# Altium schematic sheet Power.SchDoc(Power)
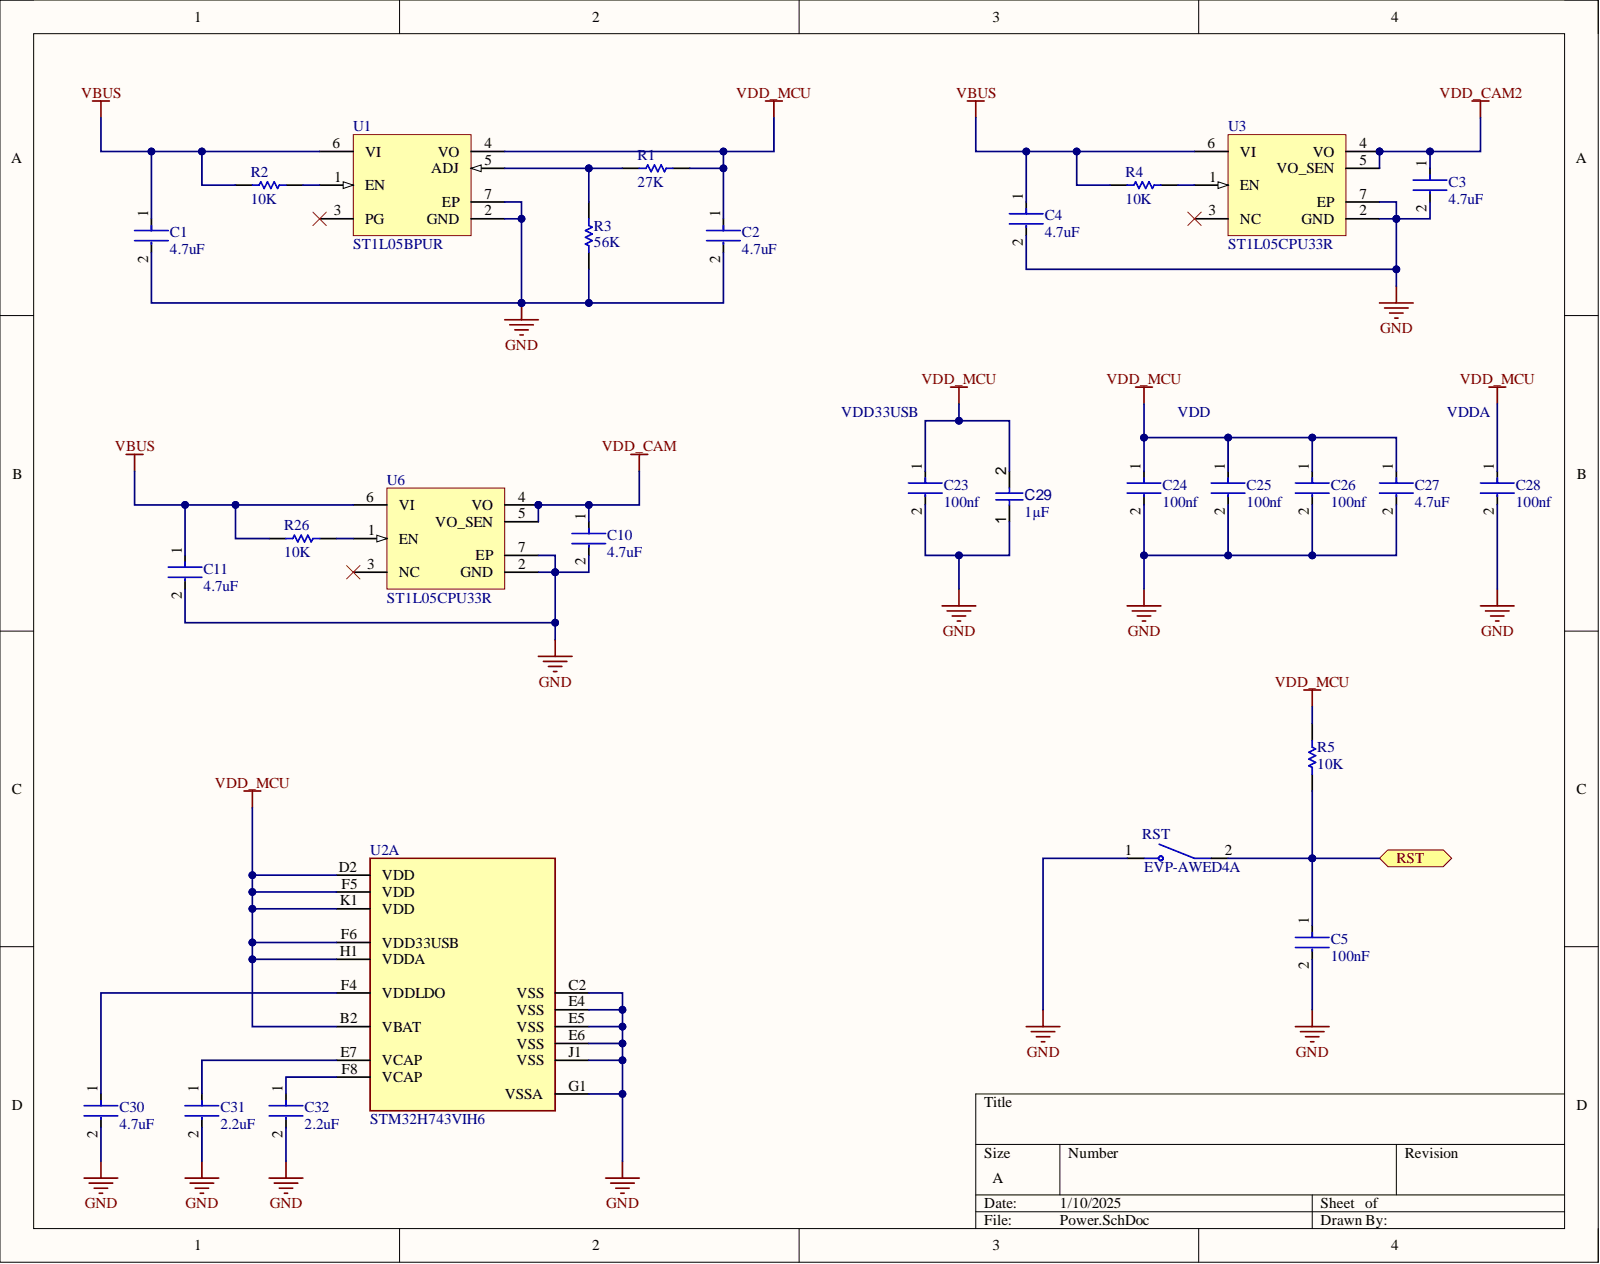
[diagram p0: Power.SchDoc]

## Parts
C1  4.7uF
C2  4.7uF
C3  4.7uF
C4  4.7uF
C5  100nF
C10  4.7uF
C11  4.7uF
C23  100nf
C24  100nf
C25  100nf
C26  100nf
C27  4.7uF
C28  100nf
C29  value 1µF  (hidden comment: Capacitor 1 uF +/- 10% 6.3 V 0402)
C30  4.7uF
C31  2.2uF
C32  2.2uF
R1  27K
R2  10K
R3  56K
R4  10K
R5  10K
R26  10K
RST  EVP-AWED4A
U1  ST1L05BPUR
U2  STM32H743VIH6  (part A)
U3  ST1L05CPU33R
U6  ST1L05CPU33R

## Ports
RST

## Nets
GND: C1.2 C2.2 C3.2 C4.2 C5.2 C10.2 C11.2 C23.2 C24.2 C25.2 C26.2 C27.2 C28.2 C29.1 C30.2 C31.2 C32.2 R3.1 RST.1 U1.2(GND) U1.7(EP) U2.C2(VSS) U2.E4(VSS) U2.E5(VSS) U2.E6(VSS) U2.G1(VSSA) U2.J1(VSS) U3.2(GND) U3.7(EP) U6.2(GND) U6.7(EP)
VBUS: C1.1 C4.1 C11.1 R2.2 R4.2 R26.2 U1.6(VI) U3.6(VI) U6.6(VI)
VDD_CAM: C10.1 U6.4(VO) U6.5(VO_SEN)
VDD_CAM2: C3.1 U3.4(VO) U3.5(VO_SEN)
VDD_MCU: C2.1 C23.1 C24.1 C25.1 C26.1 C27.1 C28.1 C29.2 R1.2 R5.1 U1.4(VO) U2.B2(VBAT) U2.D2(VDD) U2.F5(VDD) U2.F6(VDD33USB) U2.H1(VDDA) U2.K1(VDD)
RST: C5.1 R5.2 RST.2 ; port RST
NetC30_1: C30.1 U2.F4(VDDLDO)
NetC31_1: C31.1 U2.E7(VCAP)
NetC32_1: C32.1 U2.F8(VCAP)
NetR1_1: R1.1 R3.2 U1.5(ADJ)
NetR2_1: R2.1 U1.1(EN)
NetR4_1: R4.1 U3.1(EN)
NetR26_1: R26.1 U6.1(EN)

Not connected: U1 3(PG); U3 3(NC); U6 3(NC)

## Library data of the parts
C1-C4 C10 C11 C27 C30 = CMP-2000-05997-2: CAP CER 4.7UF 10V X5R 0402; Dielectric Material: Ceramic; Tolerance: 10%; Capacitance: 4.7uF; Case Code (Imperial): 0402; Case Code (Metric): 1005; Case/Package: 0402; Component Kind: Standard; Dielectric: X5R; Lead Free: Lead Free; Length: 0.039inch; Library Name: Altium Content Vault; Max Operating Temperature: 85°C; Min Operating Temperature: -55°C; Mount: Surface Mount; Package Quantity: 10000; Packaging: Cut Tape; REACH SVHC: No SVHC; RoHS Compliant: Yes; Series: C; Thickness: 0.022inch; Voltage Rating: 10V; Voltage Rating (DC): 10V; Width: 0.02inch
C5 = CMP-2008-04639-2: Chip Multilayer Ceramic Capacitors for General Purpose, 0402, 0.10uF, X7R, 15%, 10%, 50V; Dissipation Factor: 0.025%; Capacitance: 100nF; Case Code (Imperial): 0402; Case Code (Metric): 1005; Case/Package: 0402; Component Kind: Standard; Depth: 0.5mm; Dielectric: X7R; Dielectric Material: Ceramic; Height: 0.5mm; Lead Free: Lead Free; Length: 1mm; Library Name: Altium Content Vault; Material: Ceramic; Max Operating Temperature: 125°C; Min Operating Temperature: -55°C; Mount: Surface Mount; Package Quantity: 10000; Packaging: Tape and Reel; REACH SVHC: No SVHC; Resistor Type: Ceramic; Termination: SMD/SMT; Thickness: 0.022inch; Tolerance: 10%; Voltage: 50V; Voltage Rating: 50V; Voltage Rating (DC): 50V; Weight: 0.000212oz; Width: 0.5mm
C23-C26 C28 = CMP-13271-001069-1: Cap Ceramic 100nF 10V X7R ±10% SMD 0402 +125°C Paper T/R; Capacitance: 100nF; Case Code (Imperial): 0402; Case Code (Metric): 1005; Case/Package: 0402; Component Kind: Standard; Contact Plating: Tin; Depth: 0.5mm; Dielectric: X7R; Height: 0.5mm; Length: 1mm; Library Name: Altium Content Vault; Max Operating Temperature: 125°C; Min Operating Temperature: -55°C; Mount: Surface Mount; Package Quantity: 1; Packaging: Tape and Reel; Radiation Hardening: No; Thickness: 0.022inch; Tolerance: 10%; Voltage Rating: 10V; Width: 0.02inch
C29 = CMP-001-00008-6: Chip Capacitor, 1 uF, +/- 10%, 6.3 V, 0402 (1005 Metric); Capacitor Type: Ceramic; Voltage Rating: 6.3V; Case/Package: 0402; Component Kind: Standard; Max Operating Temperature: 85°C; Min Operating Temperature: -55°C; Mounting Technology: SM; Pins: 2; RoHS Compliant: True; Tolerance: 10%; Library Name: Openwater
C31 C32 = CMP-03422-000618-1: CAP CER 2.2UF 10V X5R 0402; Manufacturer: YAGEO; Manufacturer Part Number: CC0402KRX5R6BB225; Automotive Grade: NA; Material: Ceramic; Temperature Coefficient: 30ppm/°C; Tolerance: 0.1; Automotive: No; Capacitance: 2.2 uF; Category: Cap; Component Kind: Standard; ComponentLink2Description: Datasheet; ComponentLink2URL: http://www.yageo.com/documents/recent/UPY-GP_NP0_16V-to-50V_16.pdf; Device Class L1: Passive Components; Device Class L2: Capacitors; Device Class L3: Ceramic Capacitors; Digikey Description: CAP CER 2.2UF 10V X5R 0402; Footprint Url: http://www.yageo.com/exep/pages/download/literatures/UPY-C_GEN_24.pdf; Height: 0.55mm; Ipc Land Pattern Name: CAPC10050X50; Lead Free: Yes; Library Name: Altium Content Vault; Mouser Description: CC Series 0402 2.2 uF 10 V ±10% Tolerance X5R Multilayer Ceramic Capacitor; Octopart: https://octopart.com/cc0402krx5r6bb225-yageo-30987418; Package: CC0402; RoHS: TRUE; Temperature: -55°C to +125°C; Temperature Characteristic: X5R; Temperature Range High: +125°C; Temperature Range Low: -55°C; Value: 2.2 uF; Voltage Rating: 10 V
R1 = CMP-2002-07025-1: Manufacturer: Yageo / Phycomp; Part Number: RC0402FR-0727KL; Component Kind: Standard; Library Name: Altium Content Vault
R2 R4 R5 R26 = CMP-2002-07353-1: Manufacturer: Yageo / Phycomp; Part Number: RC0402FR-0710KL; Component Kind: Standard; Library Name: Altium Content Vault
R3 = CMP-1011-00708-2: Manufacturer: Yageo / Phycomp; Part Number: RC0402FR-0756KL; Component Kind: Standard; Library Name: Altium Content Vault
RST = CMP-050-000000-1: Tactile Switch SPST-NO Top Actuated Surface Mount; MF: Panasonic Electronic; Availability: Warning; Check_prices: https://www.snapeda.com/parts/EVP-AWED4A/Panasonic%20Electronic%20Components/view-part/2774278/?ref=eda; Component Kind: Standard; Component Type: Standard; Design Item ID: EVP-AWED4A; MP: EVP-AWED4A; Package: None; Pin Count: 2; Price: None; Purchase-URL: https://pricing.snapeda.com/search/part/EVP-AWED4A/?ref=eda; SnapEDA_Link: https://www.snapeda.com/parts/EVP-AWED4A/Panasonic%20Electronic%20Components/view-part/2774278/?ref=snap; Source: EVP-AWED4A.SchLib; Library Name: Openwater
U1 = CMP-0244-00292-1: Very Low Quiescent BiCMOS Voltage Regulator, Adjustable Vo, 6-Pin VFDFPN, Tape and Reel; Component Kind: Standard; ComponentLink1Description: Manufacturer URL; ComponentLink1URL: http://www.st.com; ComponentLink2Description: Datasheet; ComponentLink2URL: http://www.st.com/internet/com/TECHNICAL_RESOURCES/TECHNICAL_LITERATURE/DATASHEET/CD00186925.pdf; DatasheetVersion: 1/09/2009; Library Name: Altium Content Vault; PackageDescription: 6-Pin Plastic, Dual LLP, 3 x 3 mm Body, 0.95 mm Pitch, Exposed Pad; PackageReference: DFN6D; PackageVersion: 2008
U2 = CMP-12105-000020-1: HIGH-PERFORMANCE AND DSP WITH DP; Manufacturer: STMicroelectronics; Core Architecture: ARM Cortex-M7; Data Bus Width: 32bits; Frequency: 480MHz; Interface: I2C,SPI,UART,USART,CAN,USB,Ethernet,I2S,SMBus; Max Junction Temp: +125°C; Max Supply Voltage: 3.6V; Memory Size: 2MBytes; Memory Type: FLASH; Min Supply Voltage: 1.71V; Number Of A/D Converters: 3; Number Of Adc Channels: 36; Number Of Cores: 1; Number Of I2C Channels: 4; Number Of I/Os: 82; Number Of Pwm Channels: 2; Number Of Spi Channels: 6; Number Of Timers/Counters: 22; Number Of Uart Channels: 9; Ram Size: 1MBytes; Standoff Height: 0.15mm; Ambient Temperature Range High: +85°C; Ambient Temperature Range Low: -40°C; Automotive: No; Category: IC; Component Kind: Standard; ComponentLink2Description: Datasheet; ComponentLink2URL: https://www.st.com/resource/en/datasheet/stm32h743vi.pdf; Device Class L1: Integrated Circuits (ICs); Device Class L2: Embedded Processors and Controllers; Device Class L3: Microcontrollers; Digikey Description: HIGH-PERFORMANCE AND DSP WITH DP; Height: 1.1mm; Ipc Land Pattern Name: BGA100C80P10X10_800X800X110; Lead Free: Yes; Library Name: Altium Content Vault; Manufacturer Part Number: STM32H743VIH6; Mouser Description: MCU 32-Bit STM32H743VI ARM Cortex M7 RISC 2MB Flash 1.8V/2.5V/3.3V 100-Pin TFBGA Tray; Number Of Ethernet Channels: 1; Number Of Usb Channels: 1; Octopart: https://octopart.com/stm32h743vih6-stmicroelectronics-93590710; Package: TFBGA100-A08Q; RoHS: TRUE; Temperature: -40°C to +85°C; Temperature Range High: +85°C; Temperature Range Low: -40°C
U3 U6 = CMP-0244-00293-1: Very Low Quiescent BiCMOS Voltage Regulator, 3.3V, 6-Pin VFDFPN, Tape and Reel; Component Kind: Standard; ComponentLink1Description: Manufacturer URL; ComponentLink1URL: http://www.st.com; ComponentLink2Description: Datasheet; ComponentLink2URL: http://www.st.com/internet/com/TECHNICAL_RESOURCES/TECHNICAL_LITERATURE/DATASHEET/CD00186925.pdf; DatasheetVersion: 1/09/2009; Library Name: Altium Content Vault; PackageDescription: 6-Pin Plastic, Dual LLP, 3 x 3 mm Body, 0.95 mm Pitch, Exposed Pad; PackageReference: DFN6D; PackageVersion: 2008
